# Altium schematic sheet Sheet1.SchDoc
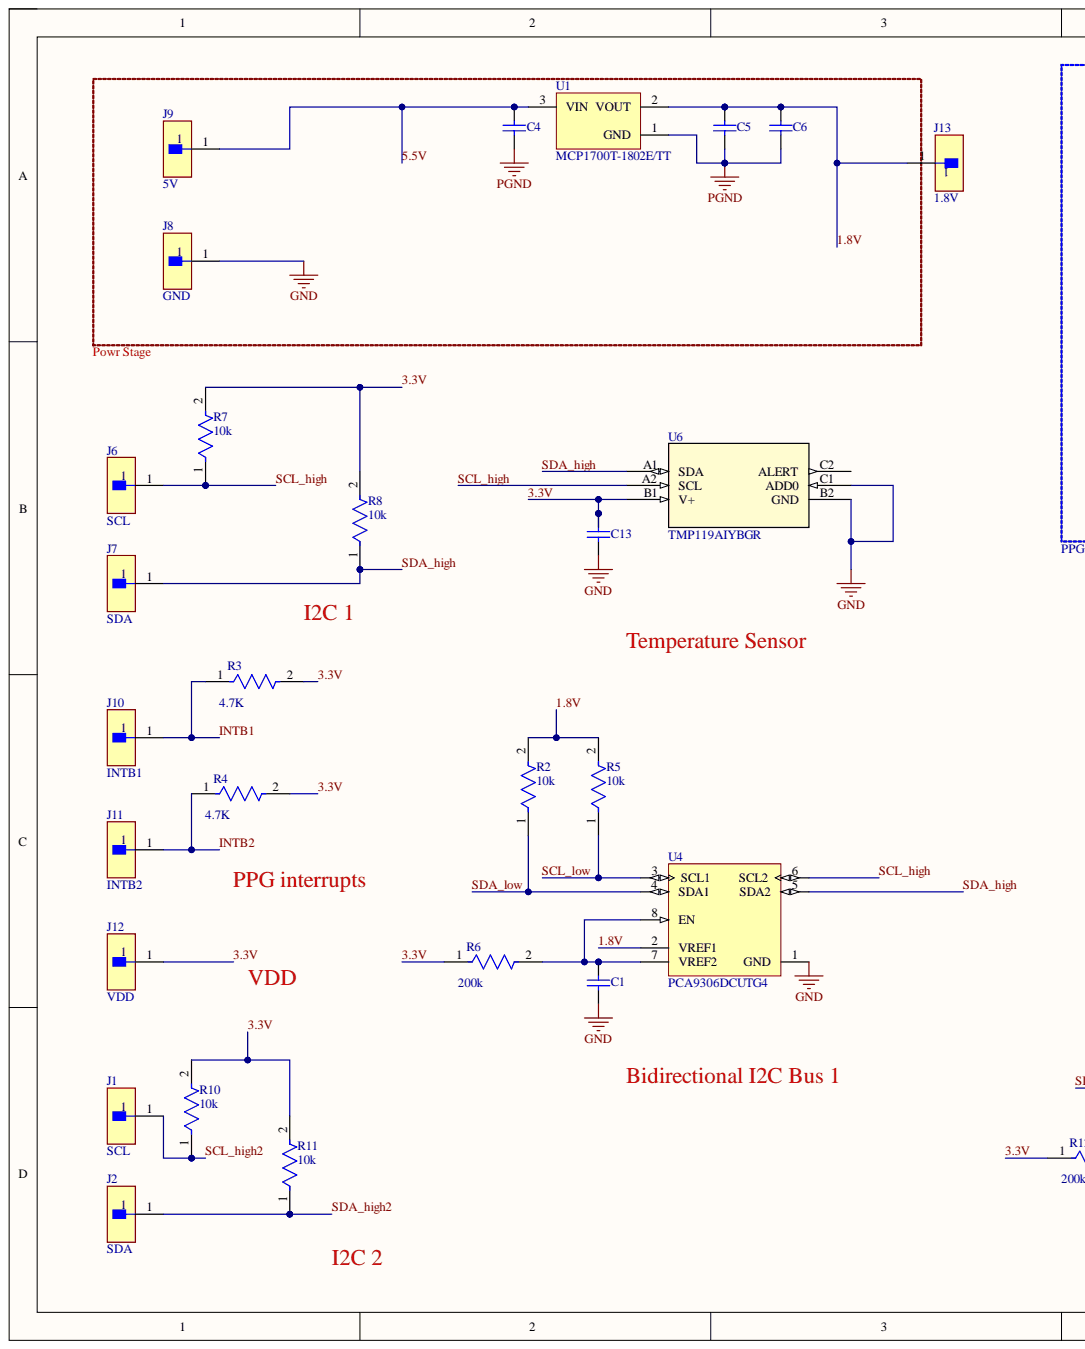
[diagram p0: Sheet1.SchDoc, left]
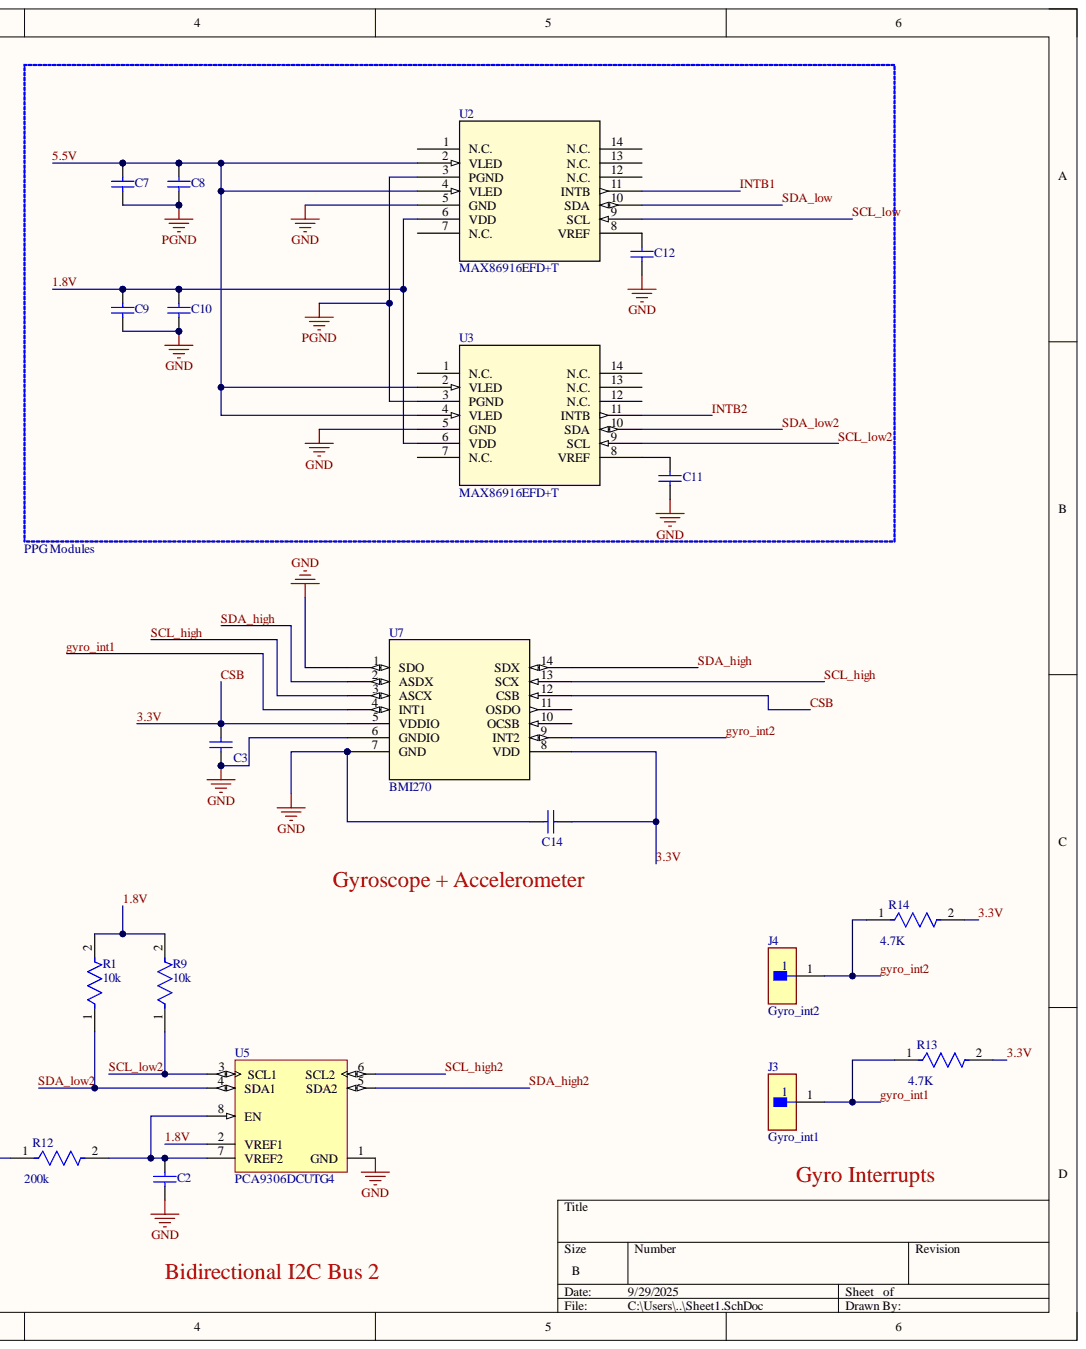
[diagram p1: Sheet1.SchDoc, right]

## Parts
C1  C0805C225K9PACTU  [CAPC2013X145X50ML20T25]  (value not drawn)
C2  C0805C225K9PACTU  [CAPC2013X145X50ML20T25]  (value not drawn)
C3  C0805C225K9PACTU  [CAPC2013X145X50ML20T25]  (value not drawn)
C4  C0805C225K9PACTU  [CAPC2013X145X50ML20T25]  (value not drawn)
C5  C0805C225K9PACTU  [CAPC2013X145X50ML20T25]  (value not drawn)
C6  C0805C225K9PACTU  [CAPC2013X145X50ML20T25]  (value not drawn)
C7  C0805C225K9PACTU  [CAPC2013X145X50ML20T25]  (value not drawn)
C8  C0805C225K9PACTU  [CAPC2013X145X50ML20T25]  (value not drawn)
C9  C0805C225K9PACTU  [CAPC2013X145X50ML20T25]  (value not drawn)
C10  C0805C225K9PACTU  [CAPC2013X145X50ML20T25]  (value not drawn)
C11  C0805C225K9PACTU  [CAPC2013X145X50ML20T25]  (value not drawn)
C12  C0805C225K9PACTU  [CAPC2013X145X50ML20T25]  (value not drawn)
C13  C0805C225K9PACTU  [CAPC2013X145X50ML20T25]  (value not drawn)
C14  C0805C225K9PACTU  [CAPC2013X145X50ML20T25]  (value not drawn)
J1  SCL  [FP-TSW-101-07-L-S-MFG]
J2  SDA  [FP-TSW-101-07-L-S-MFG]
J3  Gyro_int1  [FP-TSW-101-07-L-S-MFG]
J4  Gyro_int2  [FP-TSW-101-07-L-S-MFG]
J6  SCL  [FP-TSW-101-07-L-S-MFG]
J7  SDA  [FP-TSW-101-07-L-S-MFG]
J8  GND  [FP-TSW-101-07-L-S-MFG]
J9  5V  [FP-TSW-101-07-L-S-MFG]
J10  INTB1  [FP-TSW-101-07-L-S-MFG]
J11  INTB2  [FP-TSW-101-07-L-S-MFG]
J12  VDD  [FP-TSW-101-07-L-S-MFG]
J13  1.8V  [FP-TSW-101-07-L-S-MFG]
R1  10k  [FP-CRCW0805-e3-IPC_C]
R2  10k  [FP-CRCW0805-e3-IPC_C]
R3  4.7K  [FP-CRCW0805-e3-IPC_C]
R4  4.7K  [FP-CRCW0805-e3-IPC_C]
R5  10k  [FP-CRCW0805-e3-IPC_C]
R6  200k  [FP-CRCW0805-e3-IPC_C]
R7  10k  [FP-CRCW0805-e3-IPC_C]
R8  10k  [FP-CRCW0805-e3-IPC_C]
R9  10k  [FP-CRCW0805-e3-IPC_C]
R10  10k  [FP-CRCW0805-e3-IPC_C]
R11  10k  [FP-CRCW0805-e3-IPC_C]
R12  200k  [FP-CRCW0805-e3-IPC_C]
R13  4.7K  [FP-CRCW0805-e3-IPC_C]
R14  4.7K  [FP-CRCW0805-e3-IPC_C]
U1  MCP1700T-1802E/TT  [SOT-23-TT3_M]
U2  MAX86916EFD+T  [21-100325_F143H7MK+1_MXM]
U3  MAX86916EFD+T  [21-100325_F143H7MK+1_MXM]
U4  PCA9306DCUTG4  [DCU0008A_N]
U5  PCA9306DCUTG4  [DCU0008A_N]
U6  TMP119AIYBGR  [DSBGA6_YBG_TEX]
U7  BMI270  [BMI270_BOS]

## Nets
GND: C1.1 C2.1 C3.2 C9.1 C10.1 C11.1 C12.1 C13.1 C14.2 J8.1 U2.5(GND) U3.5(GND) U4.1(GND) U5.1(GND) U6.B2(GND) U6.C1(ADD0) U7.1(SDO) U7.6(GNDIO) U7.7(GND)
PGND: C4.1 C5.1 C6.1 C7.1 C8.1 U1.1 U2.3(PGND) U3.3(PGND)
1.8V: C5.2 C6.2 C9.2 C10.2 J13.1 R1.2 R2.2 R5.2 R9.2 U1.2 U2.6(VDD) U3.6(VDD) U4.2(VREF1) U5.2(VREF1)
3.3V: C3.1 C13.2 C14.1 J12.1 R3.2 R4.2 R6.1 R7.2 R8.2 R10.2 R11.2 R12.1 R13.2 R14.2 U6.B1(V+) U7.5(VDDIO) U7.8(VDD) U7.12(CSB)
5.5V: C4.2 C7.2 C8.2 J9.1 U1.3 U2.2(VLED) U2.4(VLED) U3.2(VLED) U3.4(VLED)
gyro_int1: J3.1 R13.1 U7.4(INT1)
gyro_int2: J4.1 R14.1 U7.9(INT2)
INTB1: J10.1 R3.1 U2.11(INTB)
INTB2: J11.1 R4.1 U3.11(INTB)
SCL_high: J6.1 R7.1 U4.6(SCL2) U6.A2(SCL) U7.3(ASCX) U7.13(SCX)
SCL_high2: J1.1 R10.1 U5.6(SCL2)
SCL_low: R5.1 U2.9(SCL) U4.3(SCL1)
SCL_low2: R9.1 U3.9(SCL) U5.3(SCL1)
SDA_high: J7.1 R8.1 U4.5(SDA2) U6.A1(SDA) U7.2(ASDX) U7.14(SDX)
SDA_high2: J2.1 R11.1 U5.5(SDA2)
SDA_low: R2.1 U2.10(SDA) U4.4(SDA1)
SDA_low2: R1.1 U3.10(SDA) U5.4(SDA1)
NetC1_2: C1.2 R6.2 U4.7(VREF2) U4.8(EN)
NetC2_2: C2.2 R12.2 U5.7(VREF2) U5.8(EN)
NetC11_2: C11.2 U3.8(VREF)
NetC12_2: C12.2 U2.8(VREF)

Not connected: U2 1(N.C.) 7(N.C.) 12(N.C.) 13(N.C.) 14(N.C.); U3 1(N.C.) 7(N.C.) 12(N.C.) 13(N.C.) 14(N.C.); U6 C2(ALERT); U7 10(OCSB) 11(OSDO)

## Library data of the parts
C1-C14 = CMP-1036-04819-2: Manufacturer: Kemet; Component Kind: Standard; Library Name: Altium Content Vault; Part Number: C0805C225K9PACTU
J1-J4 J6-J13 = CMP-02766-001775-1: CONN HEADER VERT 1POS; Gender: Male; Max Current Rating: 7.6A; Max Voltage Rating (AC): 550V; Number of Contacts: 1; Color: Black; Component Kind: Standard; Connector Type: Header; Contact Finish - Mating: GOLD; Contact Material: Bronze; Contact Mating Length: 0.23inch; Contact Plating: Gold; Contact Shape: Square; Contact Type: Male Pin; Depth: 2.54mm; Fastening Type: Pull; Flammability Rating: UL94 V-0; Housing Color: Black; Housing Material: Polyester; Insulation Color: Black; Insulation Material: PBT; Lead Free: Lead Free; Length: 2.54mm; Library Name: Altium Content Vault; Max Operating Temperature: 125°C; Min Operating Temperature: -55°C; Mount: Through Hole; Number of Positions: 1; Number of Rows: 1; Orientation: Straight; Packaging: Bulk; Radiation Hardening: No; Reach SVHC Compliant: Yes; RoHS Compliant: Yes; Series: TSW; Termination: Solder; Width: 2.54mm
R1-R14 = CMP-2001-04979-2: RES Thick Film, 16kΩ, 1%, 0.125W, 100ppm/°C, 0805; Max Power Dissipation: 125mW; Resistance: 16kR; Voltage Rating: 150V; Case Code (Imperial): 0805; Case Code (Metric): 2012; Case/Package: 0805; Component Kind: Standard; Composition: Thick Film; Contact Plating: Tin; Depth: 1.25mm; Features: Automotive AEC-Q200; Height: 0.45mm; Lead Free: Lead Free; Length: 2mm; Library Name: Altium Content Vault; Max Operating Temperature: 155°C; Military Standard: Not; Min Operating Temperature: -55°C; Mount: Surface Mount; Number of Pins: 2; Number of Terminations: 2; Package Quantity: 10; Packaging: Tape and Reel; Power Rating: 125mW; Radiation Hardening: No; Temperature Coefficient: 100ppm/°C; Termination: SMD/SMT; Tolerance: 1%; Voltage Rating (DC): 150V; Weight: 0.000194oz; Width: 1.25mm
U1 = CMP-0136-00773-1: Low Quiescent Current LDO, 3-Pin SOT-23, Extended Temperature, Tape and Reel; Code_IPC: SOT23-3; Component Kind: Standard; DatasheetVersion: revB, Feb-2007; Library Name: Altium Content Vault; PackageDescription: 3-Lead Plastic Small Outline Transistor (TT) [SOT-23]; PackageReference: SOT-23-TT3; PackageVersion: revBB, Aug-2009
U2 U3 = MAX86916EFD+T: Integrated Optical Sensor Module for Mobile Health; Manufacturer_Name: Analog Devices Inc; Component Kind: Standard; Library Name: MAX86916EFD&plus_T.SchLib; Manufacturer_Part_Number: MAX86916EFD+T; RefDes: RefDes; Type: Type; Copyright: Copyright (C) 2025 Ultra Librarian. All rights reserved.
U4 U5 = CMP-0314-00423-2: Dual Bi-Directional I2C-Bus and SMBus Voltage Level-Translator, 0 to 5.5 V, -40 to 85 degC, 8-pin US8 (DCU), Green (RoHS & no Sb/Br); Manufacturer: Texas Instruments; 5V Tolerant I/O: Yes; fSCLK(Max)(MHz): 400; Function: Voltage Translator; No. of Outputs: 2; Rating: Catalog; SubFamily: I2C Special Function; tpd max(ns): 1.5; Vcc range(V): 0 to 5.5; Code_JEDEC: MO-187-CA; Component Kind: Standard; ComponentLink1Description: Datasheet; ComponentLink1URL: http://www.ti.com/general/docs/lit/getliterature.tsp?genericPartNumber=PCA9306&fileType=pdf; ComponentLink2Description: Package Specification; ComponentLink2URL: http://www.ti.com/litv/pdf/mpds050d; DatasheetVersion: SCPS113J; Eco-Plan: Green (RoHS & no Sb/Br); Enable Pin: Yes; Library Name: Altium Content Vault; Max Frequency(kHz): 400; Mounting Technology: Surface Mount; On-Chip Power-on-Reset: No; Open Drain I/O Type: Yes; PackageDescription: 8-Pin Thin Shrink Small Outline Package 0.5 mm Pitch; PackageReference: DCU0008A; PackageVersion: revF, Jul-2005; PartNumber: PCA9306DCUTG4
U6 = TMP119AIYBGR: Â±0.08Â°C accurate digital temperature sensor in WCSP package with enhanced strain tolerance 6-DSBGA -55 to 150; Manufacturer_Name: Texas Instruments; Component Kind: Standard; Datasheet: https://www.ti.com/lit/gpn/tmp119; Library Name: TMP119AIYBGR.SchLib; Manufacturer_Part_Number: TMP119AIYBGR; RefDes: RefDes; Type: Temperature Sensors; Copyright: Copyright (C) 2025 Ultra Librarian. All rights reserved.
U7 = BMI270: No Description Available; Manufacturer_Name: Bosch Sensortec; Component Kind: Standard; Library Name: BMI270.SchLib; Manufacturer_Part_Number: BMI270; RefDes: RefDes; Type: Type; Copyright: Copyright (C) 2025 Ultra Librarian. All rights reserved.
